# Altium schematic sheet Peripherals.SchDoc
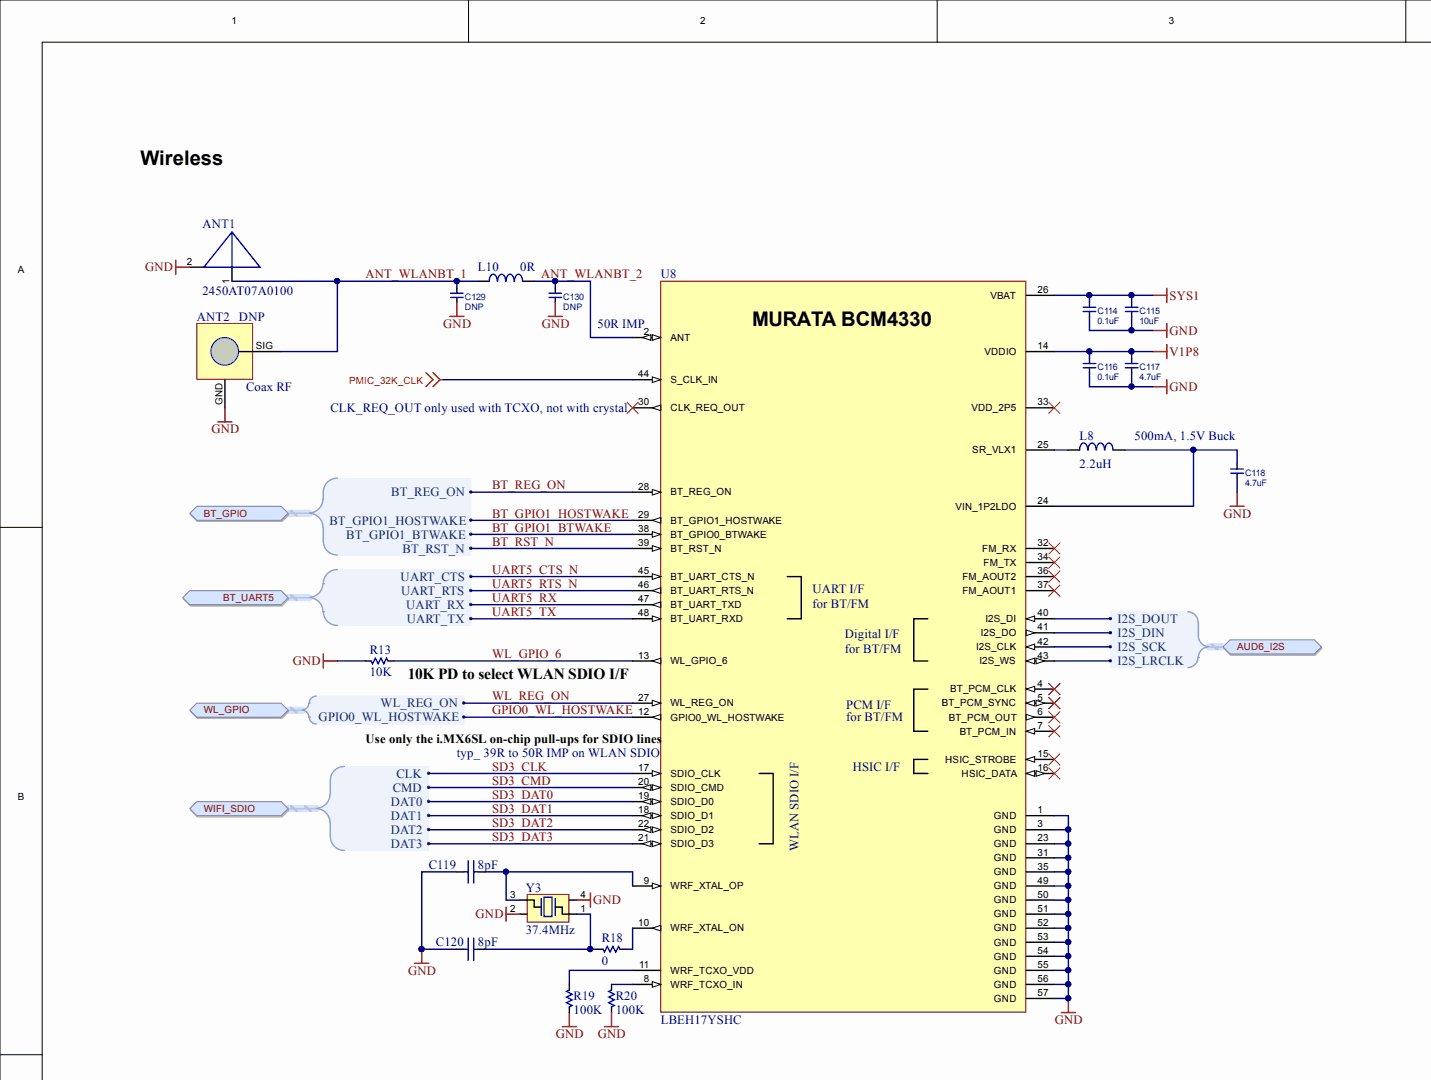
[diagram p0: Peripherals.SchDoc, top left]
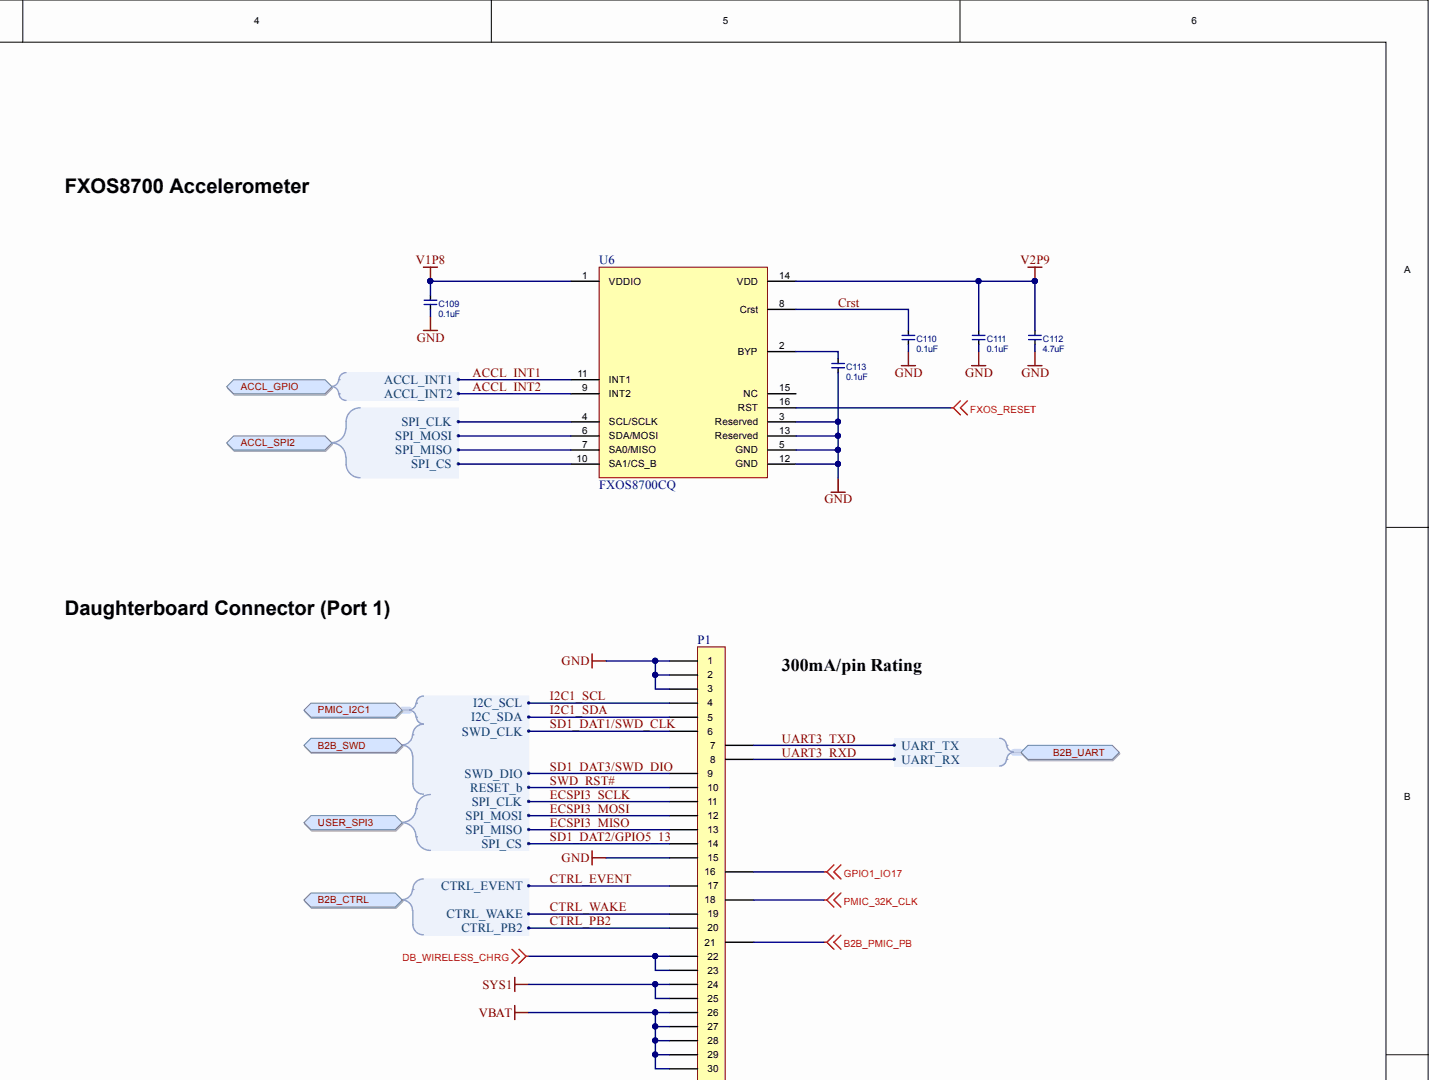
[diagram p1: Peripherals.SchDoc, top right]
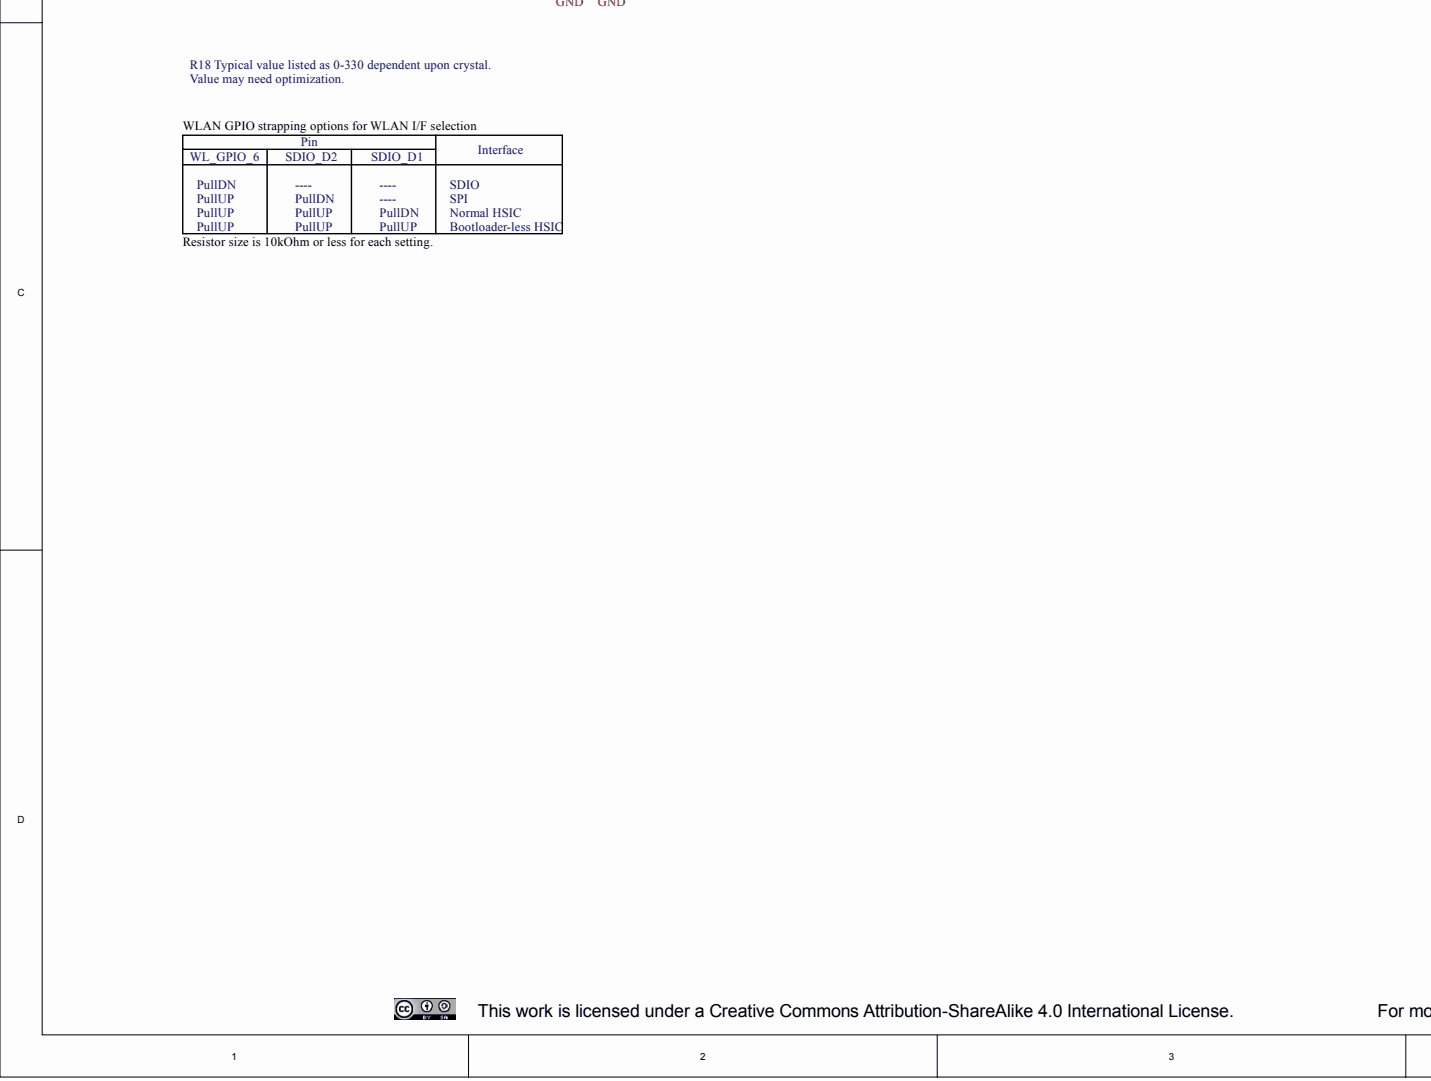
[diagram p2: Peripherals.SchDoc, bottom left]
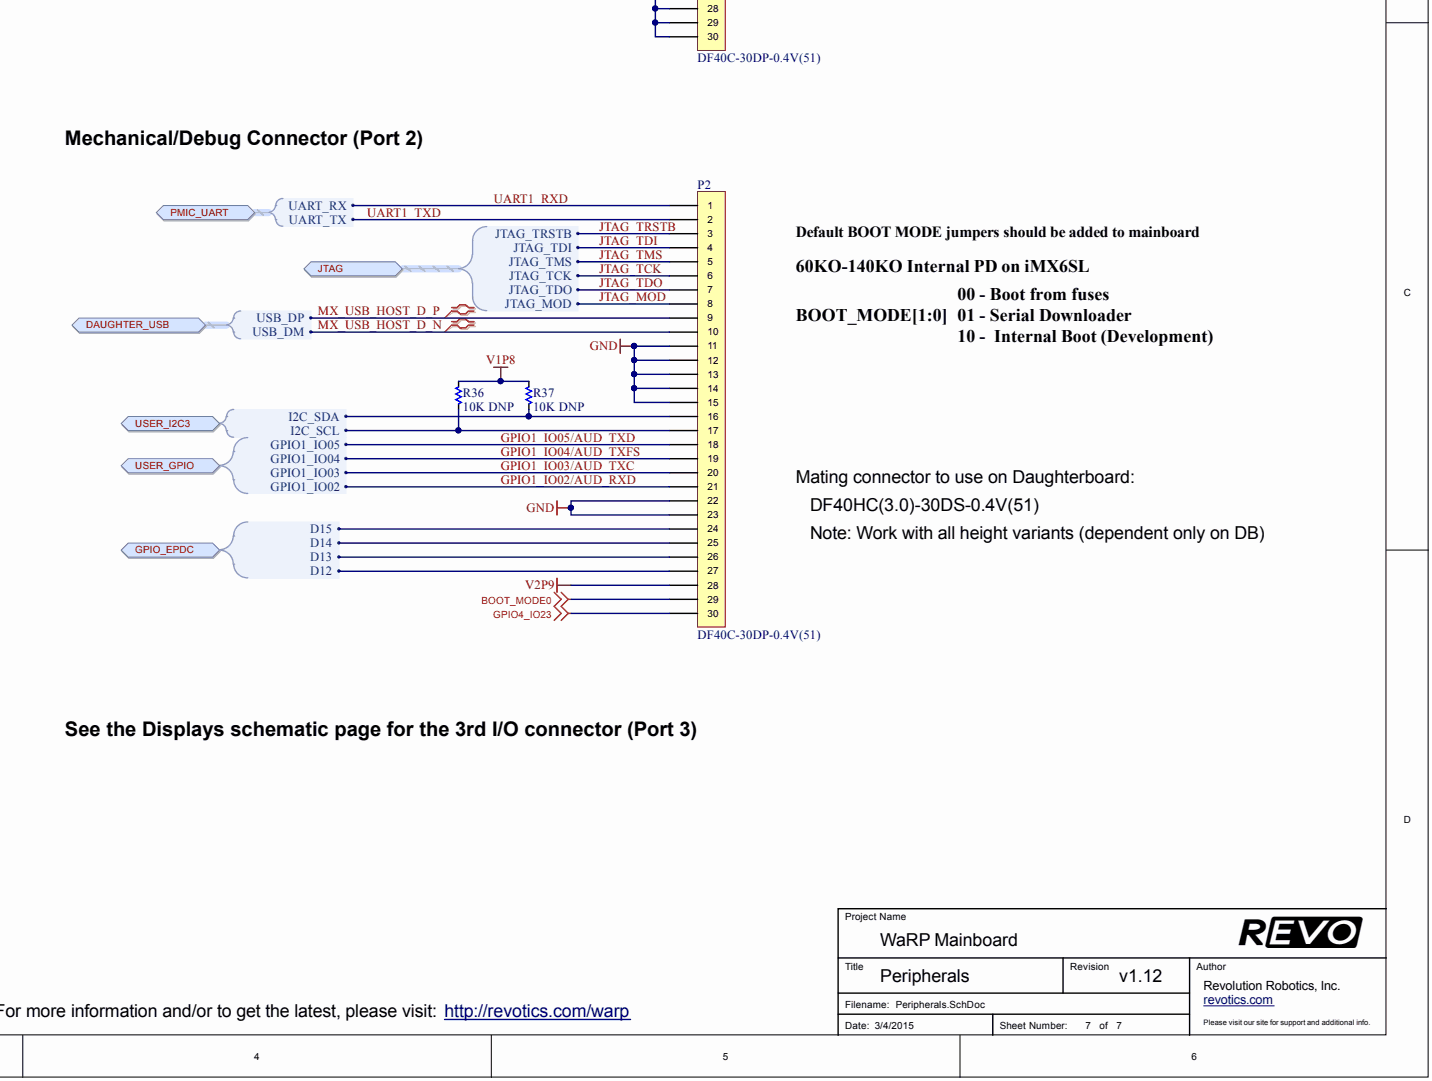
[diagram p3: Peripherals.SchDoc, bottom right]

## Parts
ANT1  2450AT07A0100  [2450AT07A0100]
ANT2  Coax RF  value DNP  [W.FL-R-SMT-1]  (no BOM)
C109  value 0.1uF  [0201 (0603) Cap High Density]  (hidden comment: CapacitorSM)
C110  value 0.1uF  [0201 (0603) Cap High Density]  (hidden comment: CapacitorSM)
C111  value 0.1uF  [0201 (0603) Cap High Density]  (hidden comment: CapacitorSM)
C112  value 4.7uF  [0402 (1005) Cap High Density]  (hidden comment: CapacitorSM)
C113  value 0.1uF  [0201 (0603) Cap High Density]  (hidden comment: CapacitorSM)
C114  value 0.1uF  [0201 (0603) Cap High Density]  (hidden comment: CapacitorSM)
C115  value 10uF  [0402 (1005) Cap High Density]  (hidden comment: CapacitorSM)
C116  value 0.1uF  [0201 (0603) Cap High Density]  (hidden comment: CapacitorSM)
C117  value 4.7uF  [0402 (1005) Cap High Density]  (hidden comment: CapacitorSM)
C118  value 4.7uF  [0402 (1005) Cap High Density]  (hidden comment: CapacitorSM)
C119  value 8pF  [0201 (0603) Cap High Density]  (hidden comment: Capacitor)
C120  value 8pF  [0201 (0603) Cap High Density]  (hidden comment: Capacitor)
C129  value DNP  Part No.: DNP  [0402 (1005) Cap High Density DNP]  (hidden comment: CapacitorSM)
C130  value DNP  Part No.: DNP  [0402 (1005) Cap High Density DNP]  (hidden comment: CapacitorSM)
L8  value 2.2uH  [0805 (2012) BRACKET]  (hidden comment: Inductor)
L10  value 0R  [0402 (1005) Cap High Density]  (hidden comment: Chip Inductor)
P1  DF40C-30DP-0.4V(51)  [DF40C-30DP-0.4V(51)]
P2  DF40C-30DP-0.4V(51)  [DF40C-30DP-0.4V(51)]
R13  Resistor  value 10K  [0201 (0603) Res High Density]
R18  Resistor  value 0  [0201 (0603) Res High Density]
R19  Resistor  value 100K  [0201 (0603) Res High Density]
R20  Resistor  value 100K  [0201 (0603) Res High Density]
R36  Resistor  value 10K DNP  [0201 (0603) Res High Density DNP]
R37  Resistor  value 10K DNP  [0201 (0603) Res High Density DNP]
U6  FXOS8700CQ  [QFN-16-COL FREESCALE]
U8  LBEH17YSHC  [LBEH17YSHC]
Y3  value 37.4MHz  [ABM12]  (hidden comment: XTAL 4Pad SMD)

## Ports
ACCL_GPIO, ACCL_GPIO.ACCL_INT1, ACCL_GPIO.ACCL_INT2, ACCL_SPI2, ACCL_SPI2.SPI_CLK, ACCL_SPI2.SPI_CS, ACCL_SPI2.SPI_MISO, ACCL_SPI2.SPI_MOSI, AUD6_I2S, AUD6_I2S.I2S_DIN, AUD6_I2S.I2S_DOUT, AUD6_I2S.I2S_LRCLK, AUD6_I2S.I2S_SCK, B2B_CTRL, B2B_CTRL.CTRL_EVENT, B2B_CTRL.CTRL_PB2, B2B_CTRL.CTRL_WAKE, B2B_SWD, B2B_SWD.RESET_B, B2B_SWD.SWD_CLK, B2B_SWD.SWD_DIO, B2B_UART, B2B_UART.UART_RX, B2B_UART.UART_TX, BT_GPIO, BT_GPIO.BT_GPIO1_BTWAKE, BT_GPIO.BT_GPIO1_HOSTWAKE, BT_GPIO.BT_REG_ON, BT_GPIO.BT_RST_N, BT_UART5, BT_UART5.UART_CTS, BT_UART5.UART_RTS, BT_UART5.UART_RX, BT_UART5.UART_TX, DAUGHTER_USB, DAUGHTER_USB.USB_DM, DAUGHTER_USB.USB_DP, GPIO_EPDC, GPIO_EPDC.D12, GPIO_EPDC.D13, GPIO_EPDC.D14, GPIO_EPDC.D15, JTAG, JTAG.JTAG_MOD, JTAG.JTAG_TCK, JTAG.JTAG_TDI, JTAG.JTAG_TDO, JTAG.JTAG_TMS, JTAG.JTAG_TRSTB, PMIC_I2C1, PMIC_I2C1.I2C_SCL, PMIC_I2C1.I2C_SDA, PMIC_UART, PMIC_UART.UART_RX, PMIC_UART.UART_TX, USER_GPIO, USER_GPIO.GPIO1_IO02, USER_GPIO.GPIO1_IO03, USER_GPIO.GPIO1_IO04, USER_GPIO.GPIO1_IO05, USER_I2C3, USER_I2C3.I2C_SCL, USER_I2C3.I2C_SDA, USER_SPI3, USER_SPI3.SPI_CLK, USER_SPI3.SPI_CS, USER_SPI3.SPI_MISO, USER_SPI3.SPI_MOSI, WIFI_SDIO, WIFI_SDIO.CLK, WIFI_SDIO.CMD, WIFI_SDIO.DAT0, WIFI_SDIO.DAT1, WIFI_SDIO.DAT2, WIFI_SDIO.DAT3, WL_GPIO, WL_GPIO.GPIO0_WL_HOSTWAKE, WL_GPIO.WL_REG_ON

## Nets
B2B_PMIC_PB: P1.21
BOOT_MODE0: P2.29
DB_WIRELESS_CHRG: P1.22 P1.23
FXOS_RESET: U6.16(RST)
GND: ANT1.2 ANT2.GND C109.2 C110.2 C111.2 C112.2 C113.2 C114.2 C115.2 C116.2 C117.2 C118.2 C119.2 C120.2 C129.2 C130.2 P1.1 P1.2 P1.3 P1.15 P2.11 P2.12 P2.13 P2.14 P2.15 P2.22 P2.23 R13.1 R19.1 R20.1 U6.3(Reserved) U6.5(GND) U6.12(GND) U6.13(Reserved) U8.1(GND) U8.3(GND) U8.23(GND) U8.31(GND) U8.35(GND) U8.49(GND) U8.50(GND) U8.51(GND) U8.52(GND) U8.53(GND) U8.54(GND) U8.55(GND) U8.56(GND) U8.57(GND) Y3.2 Y3.4
GPIO1_IO17: P1.16
GPIO4_IO23: P2.30
PMIC_32K_CLK: P1.18 U8.44(S_CLK_IN)
SYS1: C114.1 C115.1 P1.24 P1.25 U8.26(VBAT)
V1P8: C109.1 C116.1 C117.1 R36.1 R37.2 U6.1(VDDIO) U8.14(VDDIO)
V2P9: C111.1 C112.1 P2.28 U6.14(VDD)
VBAT: P1.26 P1.27 P1.28 P1.29 P1.30
ACCL_INT1: U6.11(INT1) ; port ACCL_GPIO
ACCL_INT2: U6.9(INT2) ; port ACCL_GPIO
ANT_WLANBT_1: ANT1.1 ANT2.SIG C129.1 L10.2
ANT_WLANBT_2: C130.1 L10.1 U8.2(ANT)
BT_GPIO1_BTWAKE: U8.38(BT_GPIO0_BTWAKE) ; port BT_GPIO
BT_GPIO1_HOSTWAKE: U8.29(BT_GPIO1_HOSTWAKE) ; port BT_GPIO
BT_REG_ON: U8.28(BT_REG_ON) ; port BT_GPIO
BT_RST_N: U8.39(BT_RST_N) ; port BT_GPIO
CRST: C110.1 U6.8(Crst)
CTRL_EVENT: P1.17 ; port B2B_CTRL
CTRL_PB2: P1.20 ; port B2B_CTRL
CTRL_WAKE: P1.19 ; port B2B_CTRL
ECSPI3_MISO: P1.13 ; port USER_SPI3
ECSPI3_MOSI: P1.12 ; port USER_SPI3
ECSPI3_SCLK: P1.11 ; port USER_SPI3
GPIO0_WL_HOSTWAKE: U8.12(GPIO0_WL_HOSTWAKE) ; port WL_GPIO
GPIO1_IO02/AUD_RXD: P2.21 ; port USER_GPIO
GPIO1_IO03/AUD_TXC: P2.20 ; port USER_GPIO
GPIO1_IO04/AUD_TXFS: P2.19 ; port USER_GPIO
GPIO1_IO05/AUD_TXD: P2.18 ; port USER_GPIO
I2C1_SCL: P1.4 ; port PMIC_I2C1
I2C1_SDA: P1.5 ; port PMIC_I2C1
JTAG_MOD: P2.8 ; port JTAG
JTAG_TCK: P2.6 ; port JTAG
JTAG_TDI: P2.4 ; port JTAG
JTAG_TDO: P2.7 ; port JTAG
JTAG_TMS: P2.5 ; port JTAG
JTAG_TRSTB: P2.3 ; port JTAG
MX_USB_HOST_D_N: P2.10 ; port DAUGHTER_USB
MX_USB_HOST_D_P: P2.9 ; port DAUGHTER_USB
SD1_DAT1/SWD_CLK: P1.6 ; port B2B_SWD
SD1_DAT2/GPIO5_13: P1.14 ; port USER_SPI3
SD1_DAT3/SWD_DIO: P1.9 ; port B2B_SWD
SD3_CLK: U8.17(SDIO_CLK) ; port WIFI_SDIO
SD3_CMD: U8.20(SDIO_CMD) ; port WIFI_SDIO
SD3_DAT0: U8.19(SDIO_D0) ; port WIFI_SDIO
SD3_DAT1: U8.18(SDIO_D1) ; port WIFI_SDIO
SD3_DAT2: U8.22(SDIO_D2) ; port WIFI_SDIO
SD3_DAT3: U8.21(SDIO_D3) ; port WIFI_SDIO
SWD_RST#: P1.10 ; port B2B_SWD
UART1_RXD: P2.1 ; port PMIC_UART
UART1_TXD: P2.2 ; port PMIC_UART
UART3_RXD: P1.8 ; port B2B_UART
UART3_TXD: P1.7 ; port B2B_UART
UART5_CTS_N: U8.45(BT_UART_CTS_N) ; port BT_UART5
UART5_RTS_N: U8.46(BT_UART_RTS_N) ; port BT_UART5
UART5_RX: U8.47(BT_UART_TXD) ; port BT_UART5
UART5_TX: U8.48(BT_UART_RXD) ; port BT_UART5
WL_GPIO_6: R13.2 U8.13(WL_GPIO_6)
WL_REG_ON: U8.27(WL_REG_ON) ; port WL_GPIO
NetC113_1: C113.1 U6.2(BYP)
NetC118_1: C118.1 L8.2 U8.24(VIN_1P2LDO)
NetC119_1: C119.1 U8.9(WRF_XTAL_OP) Y3.3
NetC120_1: C120.1 R18.1 Y3.1
NetL8_1: L8.1 U8.25(SR_VLX1)
NetP2_16: P2.16 R37.1 ; port USER_I2C3
NetP2_17: P2.17 R36.2 ; port USER_I2C3
NetP2_24: P2.24 ; port GPIO_EPDC
NetP2_25: P2.25 ; port GPIO_EPDC
NetP2_26: P2.26 ; port GPIO_EPDC
NetP2_27: P2.27 ; port GPIO_EPDC
NetR18_2: R18.2 U8.10(WRF_XTAL_ON)
NetR19_2: R19.2 U8.11(WRF_TCXO_VDD)
NetR20_2: R20.2 U8.8(WRF_TCXO_IN)
NetU6_4: U6.4(SCL/SCLK) ; port ACCL_SPI2
NetU6_6: U6.6(SDA/MOSI) ; port ACCL_SPI2
NetU6_7: U6.7(SA0/MISO) ; port ACCL_SPI2
NetU6_10: U6.10(SA1/CS_B) ; port ACCL_SPI2
NetU8_40: U8.40(I2S_DI) ; port AUD6_I2S
NetU8_41: U8.41(I2S_DO) ; port AUD6_I2S
NetU8_42: U8.42(I2S_CLK) ; port AUD6_I2S
NetU8_43: U8.43(I2S_WS) ; port AUD6_I2S

Not connected: U6 15(NC); U8 4(BT_PCM_CLK) 5(BT_PCM_SYNC) 6(BT_PCM_OUT) 7(BT_PCM_IN) 15(HSIC_STROBE) 16(HSIC_DATA) 30(CLK_REQ_OUT) 32(FM_RX) 33(VDD_2P5) 34(FM_TX) 36(FM_AOUT2) 37(FM_AOUT1)

## Library data of the parts
ANT1 = 2450AT07A0100: Johanson Technology Inc 1mm x 0.5mm 2.4GHz Ultra Mini Chip Antenna; Manufacturer: Johanson Technology Inc.; Vendor: Mouser; Component Kind: Standard; ComponentLink1Description: Datasheet; Library Name: Johanson Antenna.SchLib; Part No.: 2450AT07A0100; ComponentLink1URL: R:\Hardware\Part Datasheets\Antenna\Antenna_2450AT07A0100_v5.pdf
ANT2 = Coax RF: Manufacturer: Hirose; ComponentLink1Description: Datasheet; Library Name: Connectors.SchLib; Part No.: W.FL-R-SMT-1; ComponentLink1URL: R:\Hardware\Part Datasheets\Connectors\Hirose_W.FL_e32124820.pdf
C109-C111 C113 C114 C116 = CapacitorSM: Capacitor; Manufacturer: Murata; Part No.: GRM033R60J104ME19D; Vendor: Digikey; Voltage: 6.3; Component Kind: Standard; Library Name: Passive.SchLib
C112 C117 C118 = CapacitorSM: Capacitor; Manufacturer: TDK; Part No.: C1005X5R0J475M050BC; Vendor: Digikey; Voltage: 6.3; Component Kind: Standard; Library Name: Passive.SchLib
C115 = CapacitorSM: Capacitor; Manufacturer: Samsung; Part No.: CL05A106MQ5NUNC; Vendor: Digikey; Voltage: 6.3; Component Kind: Standard; Library Name: Passive.SchLib
C119 C120 = Capacitor: Manufacturer: TDK; Part No.: C0603C0G1E080D030BA; Tolerance: 0.05pF; Vendor: Digikey; Voltage: 50; Component Kind: Standard; Description: Capacitor; Library Name: Passive.SchLib; Package: 0402; STOCK: Yes
C129 C130 = CapacitorSM: Capacitor; Manufacturer: Murata; Vendor: Digikey; Component Kind: Standard; Library Name: Passive.SchLib
L8 = Inductor: Manufacturer: Samsung; Part No.: CIG21L2R2MNE; Properties: 160mO, 950mA max; Vendor: AVNET; Component Kind: Standard; Description: Inductor; Library Name: Passive.SchLib
L10 = Chip Inductor: Inductor; Manufacturer: Vishay Dale; Part No.: RCG04020000Z0ED; Vendor: Digikey; Component Kind: Standard; Library Name: Passive.SchLib
P1 P2 = DF40C-30DP-0.4V(51): 30pin, 0.4mm, Hirose B2B Connector; Vendor: Digikey; Component Kind: Standard; ComponentLink1Description: Datasheet; Library Name: Hirose Connectors.SchLib; Manufacturer: Hirose; Part No.: DF40C-30DP-0.4V(51); ComponentLink1URL: R:\Hardware\Part Datasheets\Connectors\ed_DF40_20120316.pdf
R13 R36 R37 = Resistor: Manufacturer: Vishay / Dale; Part No.: CRCW020110K0FNED; Part No. Ref: CRCW[PACKAGE][VALUE]; Vendor: Digikey; Component Kind: Standard; Description: Resistor; Library Name: Passive.SchLib; Simulation: RES
R18 = Resistor: Manufacturer: Vishay / Dale; Part No.: CRCW02010000Z0ED; Part No. Ref: CRCW[PACKAGE][VALUE]; Vendor: Digkey; Component Kind: Standard; Description: Resistor; Library Name: Passive.SchLib; Simulation: RES
R19 R20 = Resistor: Manufacturer: Vishay / Dale; Part No.: CRCW0201100KFNED; Part No. Ref: CRCW[PACKAGE][VALUE]; Vendor: Digikey; Component Kind: Standard; Description: Resistor; Library Name: Passive.SchLib; Simulation: RES
U6 = FXOS8700CQ: 6-Axis Accelerometer; Manufacturer: Freescale; Component Kind: Standard; ComponentLink1Description: Datasheet; Library Name: Freescale.SchLib; Part No.: FXOS8700CQ; Supplier 1: Newark; Supplier Part Number 1: 47W8598; ComponentLink1URL: R:\Hardware\Part Datasheets\Accelerometer\FXOS8700CQ-60595.pdf
U8 = LBEH17YSHC: Murata LBEH17YSHC 802.11b/g/n+BT4.0+EDR+FM TX/RX; Component Kind: Standard; ComponentLink1Description: Datasheet; Library Name: MurataWireless.SchLib; Manufacturer: Murata; Part no.: LBEH17YSHC; ComponentLink1URL: R:\Hardware\Part Datasheets\WiFi\SP-7YSH-G.pdf
Y3 = XTAL 4Pad SMD: ABM12-37.400MHz-12-R60-B2X-T; Manufacturer: Abracon; Part No.: ABM12-104-37.400MHZ-T; Vendor: Abracon/ATMI; Alt. Part No.: ABM12-37.400MHz-12-R60-B2X-T; Component Kind: Standard; Library Name: XTAL.SchLib
